# Altium schematic sheet ADC_Subsystem.SchDoc
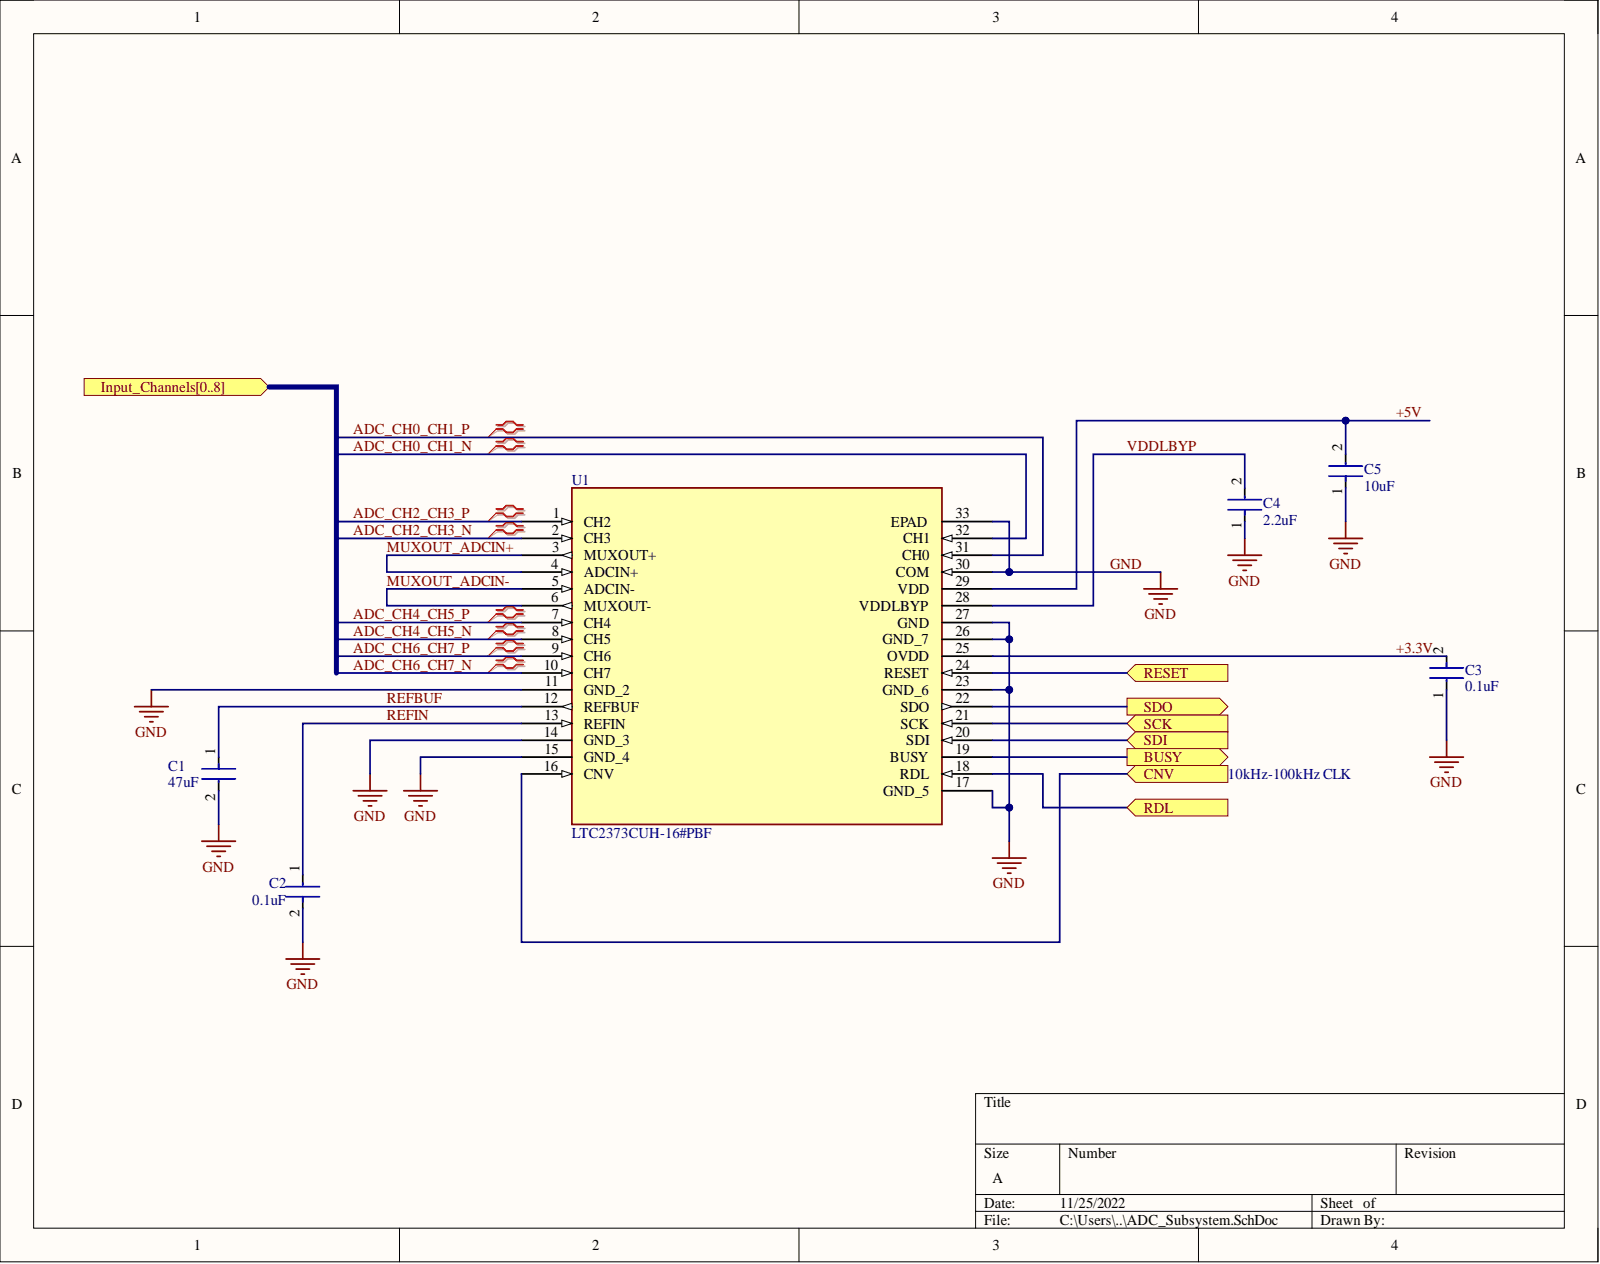
[diagram p0: ADC_Subsystem.SchDoc]

## Parts
C1  47uF  [FP-C0805C476M9PACTU-MFG]
C2  0.1uF  [FP-GRM15-MFG]
C3  0.1uF  [FP-GRM15-MFG]
C4  2.2uF  [FP-GRM188-0_1-e0_2_0_5-MFG]
C5  10uF  [FP-GRM188-0_1-e0_2_0_55-IPC_A]
U1  LTC2373CUH-16#PBF  [LTC_2373_Footprint]

## Ports
BUSY, CNV, Input_Channels0, Input_Channels1, Input_Channels2, Input_Channels3, Input_Channels4, Input_Channels5, Input_Channels6, Input_Channels7, Input_Channels8, Input_Channels[0..8], RDL, RESET, SCK, SDI, SDO

## Nets
+3.3V: C3.2 U1.25(OVDD)
+5V: C5.2 U1.29(VDD)
ADC_CH0_CH1_N: U1.32(CH1)
ADC_CH0_CH1_P: U1.31(CH0)
ADC_CH2_CH3_N: U1.2(CH3)
ADC_CH2_CH3_P: U1.1(CH2)
ADC_CH4_CH5_N: U1.8(CH5)
ADC_CH4_CH5_P: U1.7(CH4)
ADC_CH6_CH7_N: U1.10(CH7)
ADC_CH6_CH7_P: U1.9(CH6)
BUSY: U1.19(BUSY) ; port BUSY
CNV: U1.16(CNV) ; port CNV
GND: C1.2 C2.2 C3.1 C4.1 C5.1 U1.11(GND_2) U1.14(GND_3) U1.15(GND_4) U1.17(GND_5) U1.23(GND_6) U1.26(GND_7) U1.27(GND) U1.30(COM) U1.33(EPAD)
MUXOUT_ADCIN+: U1.3(MUXOUT+) U1.4(ADCIN+)
MUXOUT_ADCIN-: U1.5(ADCIN-) U1.6(MUXOUT-)
RDL: U1.18(RDL) ; port RDL
REFBUF: C1.1 U1.12(REFBUF)
REFIN: C2.1 U1.13(REFIN)
RESET: U1.24(RESET) ; port RESET
SCK: U1.21(SCK) ; port SCK
SDI: U1.20(SDI) ; port SDI
SDO: U1.22(SDO) ; port SDO
VDDLBYP: C4.2 U1.28(VDDLBYP)
N00001_0: ; port Input_Channels[0..8]
N00001_1: ; port Input_Channels[0..8]
N00001_2: ; port Input_Channels[0..8]
N00001_3: ; port Input_Channels[0..8]
N00001_4: ; port Input_Channels[0..8]
N00001_5: ; port Input_Channels[0..8]
N00001_6: ; port Input_Channels[0..8]
N00001_7: ; port Input_Channels[0..8]
N00001_8: ; port Input_Channels[0..8]

## Library data of the parts
C1 = CMP-2007-01008-2: CAP CER 47UF 6.3V X5R 0805  C0805C476M9PACTU; Dielectric Material: Ceramic; Dissipation Factor: 5%; Tolerance: 20%; Capacitance: 47uF; Case Code (Imperial): 0805; Case Code (Metric): 2012; Case/Package: 0805; Component Kind: Standard; Construction: Flat; Depth: 1.25mm; Dielectric: X5R; Height: 1.25mm; Length: 2mm; Library Name: Altium Content Vault; Max Operating Temperature: 85°C; Min Operating Temperature: -55°C; Mount: PCB; Number of Pins: 2; Package Quantity: 3000; Packaging: Tape and Reel; Radiation Hardening: No; REACH SVHC: No SVHC; RoHS Compliant: Yes; Series: C0805C; Termination: SMD/SMT; Voltage: 6.3V; Voltage Rating: 6.3V; Voltage Rating (DC): 6.3V; Width: 1.2mm
C2 C3 = CMP-2100-03641-2: CAP CER 0.1UF 16V X7R 0402  GRM155R71C104KA88D; Manufacturer: Murata; Capacitance: 0.10μF; Material: Ceramic; Standoff Height: 0mm; Tolerance: 10%; Value: 0.10μF; Voltage Rating: 16Vdc; Category: Cap; Component Kind: Standard; ComponentLink2Description: Datasheet; ComponentLink2URL: https://search.murata.co.jp/Ceramy/image/img/A01X/G101/ENG/GRM155R71H471KA01-01A.pdf; Device Class L1: Passive Components; Device Class L2: Capacitors; Device Class L3: Ceramic Capacitors; Digikey Description: CAP CER 0.1UF 16V X7R 0402; Height: 0.55mm; Ipc Land Pattern Name: CAPC10050X219; Lead Free: yes; Library Name: Altium Content Vault; Manufacturer Part Number: GRM155R71C104KA88D; Mouser Description: 0402 0.1 uF 16V ±10 % Tolerance X7R SMT Multilayer Ceramic Capacitor; Octopart: https://octopart.com/grm155r71c104ka88d-murata-196880; Package: GRM15; RoHS: TRUE; Temperature: -55°C to +125°C; Temperature Characteristic: X7R; Temperature Range High: +125°C; Temperature Range Low: -55°C
C4 = CMP-2006-02836-2: Chip Multilayer Ceramic Capacitors for General Purpose, 0603, 2.2uF, X7R, 15%, 10%, 10V  GRM188R71A225KE15D; Capacitance: 2.2uF; Case Code (Imperial): 0603; Case Code (Metric): 1608; Case/Package: 0603; Component Kind: Standard; Depth: 0.8mm; Dielectric: X7R; Height: 0.8mm; Lead Free: Lead Free; Length: 1.6mm; Library Name: Altium Content Vault; Material: Ceramic; Max Operating Temperature: 125°C; Min Operating Temperature: -55°C; Mount: Surface Mount; Number of Pins: 2; Package Quantity: 1; Packaging: Cut Tape; REACH SVHC: No SVHC; Termination: SMD/SMT; Thickness: 0.035inch; Tolerance: 10%; Voltage: 10V; Voltage Rating: 10V; Voltage Rating (DC): 10V; Weight: 7.1E-05oz; Width: 0.031inch
C5 = CMP-2006-02792-2: Chip Multilayer Ceramic Capacitors for General Purpose, 0603, 10uF, X5R, 15%, 20%, 6.3V  GRM188R60J106ME47D; Capacitance: 10uF; Case Code (Imperial): 0603; Case Code (Metric): 1608; Case/Package: 0603; Component Kind: Standard; Depth: 0.8mm; Dielectric: X5R; Height: 0.8mm; Lead Free: Lead Free; Length: 1.6mm; Library Name: Altium Content Vault; Material: Ceramic; Max Operating Temperature: 85°C; Min Operating Temperature: -55°C; Mount: Surface Mount; Package Quantity: 4000; Packaging: Tape and Reel; Radiation Hardening: No; REACH SVHC: No SVHC; Termination: SMD/SMT; Thickness: 0.035inch; Tolerance: 20%; Voltage: 6.3V; Voltage Rating: 6.3V; Voltage Rating (DC): 6.3V; Width: 0.031inch
U1 = LTC2373CUH-16#PBF: Component Kind: Standard; Library Name: LTC2373CUH-16#PBF.SchLib
